# Altium schematic sheet uController.SchDoc
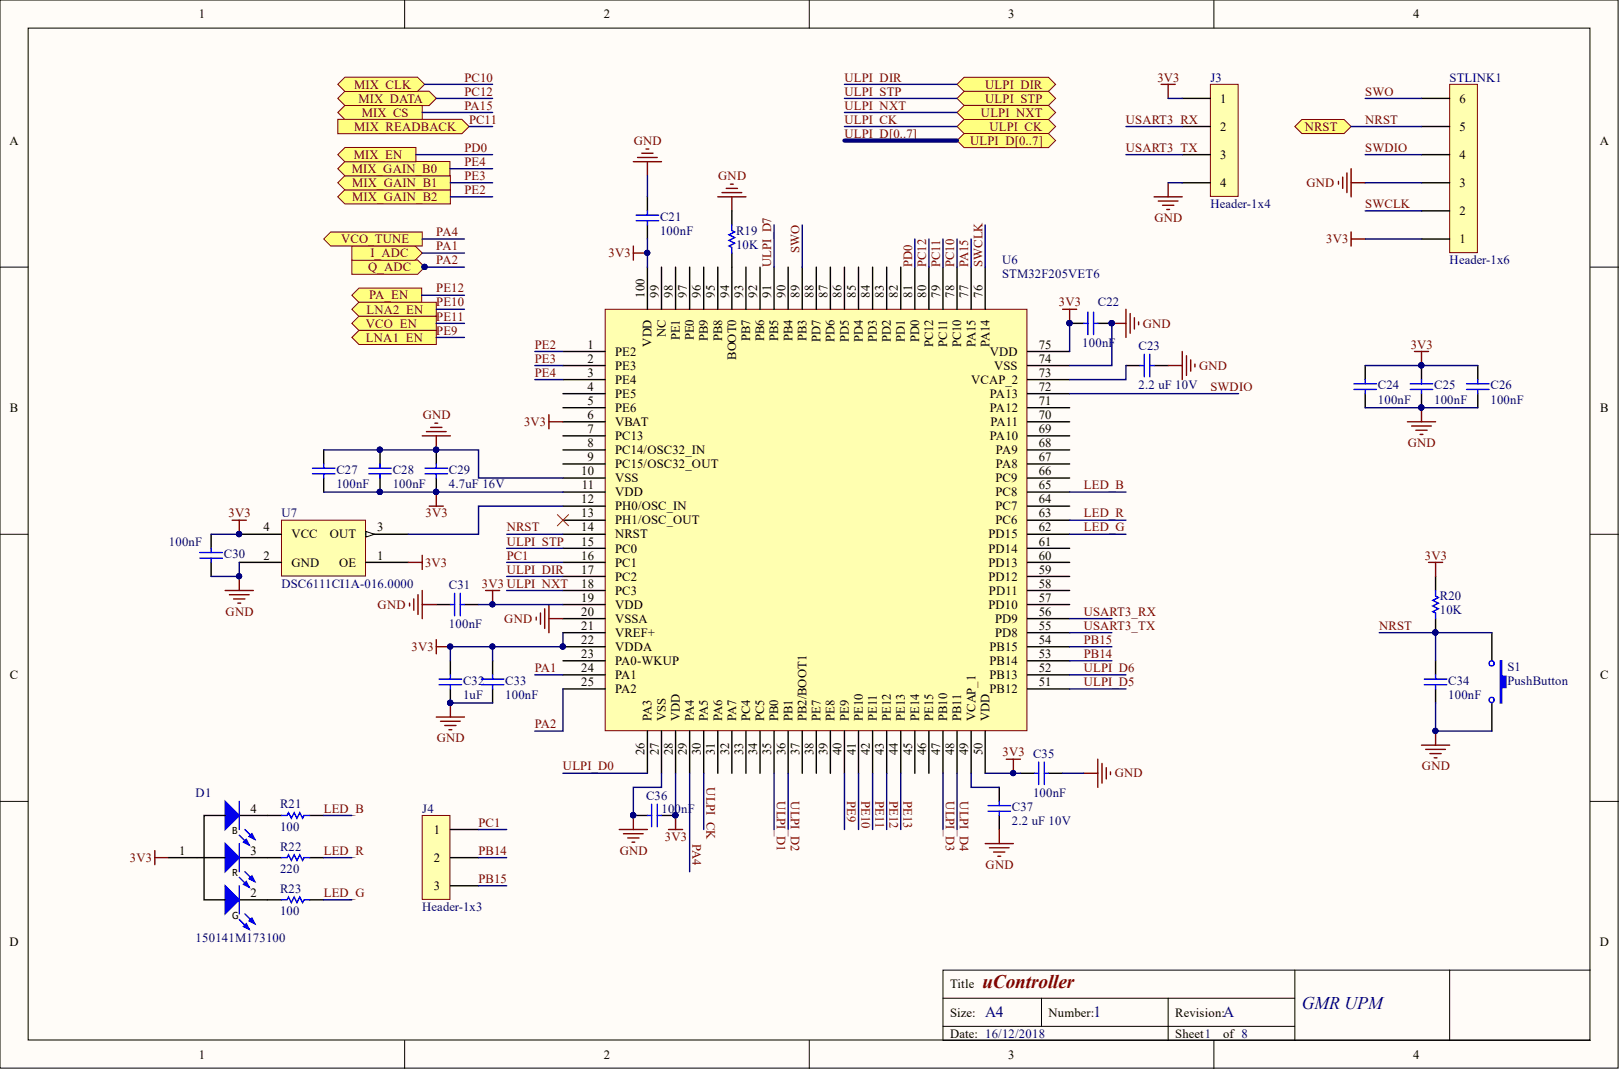
[diagram p0: uController.SchDoc]

## Parts
C21  100nF  [0603 Cap]
C22  100nF  [0603 Cap]
C23  2.2 uF 10V  Voltage - Rated: 10V  [0603 Cap]
C24  100nF  [0603 Cap]
C25  100nF  [0603 Cap]
C26  100nF  [0603 Cap]
C27  100nF  [0603 Cap]
C28  100nF  [0603 Cap]
C29  4.7uF 16V  [0805 CAP]
C30  100nF  [0603 Cap]
C31  100nF  [0603 Cap]
C32  1uF  [0603 Cap]
C33  100nF  [0603 Cap]
C34  100nF  [0603 Cap]
C35  100nF  [0603 Cap]
C36  100nF  [0603 Cap]
C37  2.2 uF 10V  [0603 Cap]
D1  150141M173100  [DFN270P320X210-4N]
J3  Header-1x4  [HEADER-1X4]
J4  Header-1x3  [Header-1x3-Jumper]
R19  10K  [0603 Res]
R20  10K  [0603 Res]
R21  100  [RESC1005X04L]
R22  220  [RESC1005X04L]
R23  100  [RESC1005X04L]
S1  PushButton  [GH7643CT-ND]
STLINK1  Header-1x6  [Header-1x6]
U6  STM32F205VET6  [LQFP-100]
U7  DSC6111CI1A-016.0000  [DFN 3.2x2.5]

## Ports
I_ADC, LNA1_EN, LNA2_EN, MIX_CLK, MIX_CS, MIX_DATA, MIX_EN, MIX_GAIN_B0, MIX_GAIN_B1, MIX_GAIN_B2, MIX_READBACK, NRST, PA_EN, Q_ADC, ULPI_CK, ULPI_D0, ULPI_D1, ULPI_D2, ULPI_D3, ULPI_D4, ULPI_D5, ULPI_D6, ULPI_D7, ULPI_D[0..7], ULPI_DIR, ULPI_NXT, ULPI_STP, VCO_EN, VCO_TUNE

## Nets
3V3: C21.2 C22.1 C24.2 C25.2 C26.2 C27.1 C28.1 C29.1 C30.2 C31.1 C32.2 C33.1 C35.2 C36.1 D1.1 J3.1 R20.2 STLINK1.1 U6.6(VBAT) U6.11(VDD) U6.19(VDD) U6.21(VREF+) U6.22(VDDA) U6.28(VDD) U6.50(VDD) U6.75(VDD) U6.100(VDD) U7.1(OE) U7.4(VCC)
GND: C21.1 C22.2 C23.1 C24.1 C25.1 C26.1 C27.2 C28.2 C29.2 C30.1 C31.2 C32.1 C33.2 C34.1 C35.1 C36.2 C37.1 J3.4 R19.2 S1.2 STLINK1.3 U6.10(VSS) U6.20(VSSA) U6.27(VSS) U6.74(VSS) U7.2(GND)
LED_B: R21.2 U6.65(PC8)
LED_G: R23.2 U6.62(PD15)
LED_R: R22.2 U6.63(PC6)
NRST: C34.2 R20.1 S1.1 STLINK1.5 U6.14(NRST) ; port NRST
PA1: U6.24(PA1) ; port I_ADC
PA2: U6.25(PA2) ; port Q_ADC
PA4: U6.29(PA4) ; port VCO_TUNE
PA15: U6.77(PA15) ; port MIX_CS
PB14: J4.2 U6.53(PB14)
PB15: J4.3 U6.54(PB15)
PC1: J4.1 U6.16(PC1)
PC10: U6.78(PC10) ; port MIX_CLK
PC11: U6.79(PC11) ; port MIX_READBACK
PC12: U6.80(PC12) ; port MIX_DATA
PD0: U6.81(PD0) ; port MIX_EN
PE2: U6.1(PE2) ; port MIX_GAIN_B2
PE3: U6.2(PE3) ; port MIX_GAIN_B1
PE4: U6.3(PE4) ; port MIX_GAIN_B0
PE9: U6.40(PE9) ; port LNA1_EN
PE10: U6.41(PE10) ; port LNA2_EN
PE11: U6.42(PE11) ; port VCO_EN
PE12: U6.43(PE12) ; port PA_EN
PE13: U6.44(PE13)
SWCLK: STLINK1.2 U6.76(PA14)
SWDIO: STLINK1.4 U6.72(PA13)
SWO: STLINK1.6 U6.89(PB3)
ULPI_CK: U6.30(PA5) ; port ULPI_CK
ULPI_D0: U6.26(PA3) ; port ULPI_D[0..7]  [also labelled ULPI_D]
ULPI_D1: U6.35(PB0) ; port ULPI_D[0..7]  [also labelled ULPI_D]
ULPI_D2: U6.36(PB1) ; port ULPI_D[0..7]  [also labelled ULPI_D]
ULPI_D3: U6.47(PB10) ; port ULPI_D[0..7]  [also labelled ULPI_D]
ULPI_D4: U6.48(PB11) ; port ULPI_D[0..7]  [also labelled ULPI_D]
ULPI_D5: U6.51(PB12) ; port ULPI_D[0..7]  [also labelled ULPI_D]
ULPI_D6: U6.52(PB13) ; port ULPI_D[0..7]  [also labelled ULPI_D]
ULPI_D7: U6.91(PB5) ; port ULPI_D[0..7]  [also labelled ULPI_D]
ULPI_DIR: U6.17(PC2) ; port ULPI_DIR
ULPI_NXT: U6.18(PC3) ; port ULPI_NXT
ULPI_STP: U6.15(PC0) ; port ULPI_STP
USART3_RX: J3.2 U6.56(PD9)
USART3_TX: J3.3 U6.55(PD8)
NetC23_2: C23.2 U6.73(VCAP_2)
NetC37_2: C37.2 U6.49(VCAP_1)
NetD1_2: D1.2 R23.1
NetD1_3: D1.3 R22.1
NetD1_4: D1.4 R21.1
NetR19_1: R19.1 U6.94(BOOT0)
NetU6_12: U6.12(PH0/OSC_IN) U7.3(OUT)

Not connected: U6 4(PE5) 5(PE6) 7(PC13) 8(PC14/OSC32_IN) 9(PC15/OSC32_OUT) 13(PH1/OSC_OUT) 23(PA0-WKUP) 31(PA6) 32(PA7) 33(PC4) 34(PC5) 37(PB2/BOOT1) 38(PE7) 39(PE8) 45(PE14) 46(PE15) 57(PD10) 58(PD11) 59(PD12) 60(PD13) 61(PD14) 64(PC7) 66(PC9) 67(PA8) 68(PA9) 69(PA10) 70(PA11) 71(PA12) 82(PD1) 83(PD2) 84(PD3) 85(PD4) 86(PD5) 87(PD6) 88(PD7) 90(PB4) 92(PB6) 93(PB7) 95(PB8) 96(PB9) 97(PE0) 98(PE1) 99(NC)

## Library data of the parts
C21 C22 C24-C28 C30 C31 C33-C36 = C0603C104K4RACTU: CAP CER 0.1UF 16V X7R 0603; Manufacturer: KEMET; Capacitance: 0.1µF; Tolerance: ±10%; Applications: General Purpose; Category: Capacitors; Component Kind: Standard; ComponentLink1Description: http://www.kemet.com/docfinder?Partnumber=C0603C104K4RACTU; ComponentLink1URL: http://www.kemet.com/docfinder?Partnumber=C0603C104K4RACTU; Failure Rate: -; Features: -; Height - Seated (Max): -; Lead Spacing: -; Lead Style: -; Library Name: Componentes_base.SchLib; Manufacturer Part Number: C0603C104K4RACTU; Mounting Type: Surface Mount, MLCC; Operating Temperature: -55°C ~ 125°C; Package / Case: 0603 (1608 Metric); Packaging: Digi-Reel®; Part Status: Active; Pricing 1: 1=0.09, 10=0.022, 25=0.016, 50=0.0122, 100=0.01, 250=0.00836, 500=0.0071, 1000=0.00559 (EUR); Ratings: -; RoHS: RoHS Compliant; Size / Dimension: 0.063" L x 0.031" W (1.60mm x 0.80mm); Stock 1: 4491346; Supplier 1: Digi-Key; Supplier Part Number 1: 399-1096-6-ND; Temperature Coefficient: X7R; Thickness (Max): 0.034" (0.87mm); Voltage - Rated: 16V
C23 C37 = CL10B225KP8NNNC: CAP CER 2.2UF 10V X7R 0603; Manufacturer: Samsung Electro-Mechanics America, Inc.; Capacitance: 2.2µF; Tolerance: ±10%; Applications: General Purpose; Category: Capacitors; Component Kind: Standard; ComponentLink1Description: http://www.samsungsem.com/kr/support/product-search/mlcc/__icsFiles/afieldfile/2016/08/18/S_CL10B225KP8NNNC.pdf; ComponentLink1URL: http://www.samsungsem.com/kr/support/product-search/mlcc/__icsFiles/afieldfile/2016/08/18/S_CL10B225KP8NNNC.pdf; Features: -; Height - Seated (Max): -; Lead Spacing: -; Lead Style: -; Library Name: Componentes_base.SchLib; Manufacturer Part Number: CL10B225KP8NNNC; Mounting Type: Surface Mount, MLCC; Operating Temperature: -55°C ~ 125°C; Package / Case: 0603 (1608 Metric); Packaging: Digi-Reel®; Part Status: Active; Pricing 1: 1=0.15, 10=0.105, 25=0.0764, 50=0.0592, 100=0.0491, 250=0.04068, 500=0.03444, 1000=0.02851 (EUR); Ratings: -; RoHS: RoHS Compliant; Size / Dimension: 0.063" L x 0.031" W (1.60mm x 0.80mm); Stock 1: 226342; Supplier 1: Digi-Key; Supplier Part Number 1: 1276-1134-6-ND; Temperature Coefficient: X7R; Thickness (Max): 0.035" (0.90mm)
  C37: Voltage - Rated: 10V
C29 = GRM21BR71C475KE51L: CAP CER 4.7UF 16V X7R 0805; Manufacturer: Murata Electronics North America; Capacitance: 4.7µF; Tolerance: ±10%; Applications: General Purpose; Category: Capacitors; Component Kind: Standard; Failure Rate: -; Features: -; Height - Seated (Max): -; Lead Spacing: -; Lead Style: -; Library Name: Componentes_base.SchLib; Manufacturer Part Number: GRM21BR71C475KE51L; Mounting Type: Surface Mount, MLCC; Operating Temperature: -55°C ~ 125°C; Package / Case: 0805 (2012 Metric); Part Status: Active; Pricing 1: 1=0.16, 10=0.111, 25=0.0816, 50=0.0666, 100=0.0562, 250=0.04588, 500=0.03848, 1000=0.03403 (EUR); Ratings: -; RoHS: RoHS Compliant; Size / Dimension: 0.079" L x 0.049" W (2.00mm x 1.25mm); Stock 1: 5810; Supplier 1: Digi-Key; Supplier Part Number 1: 490-14466-6-ND; Temperature Coefficient: X7R; Thickness (Max): 0.055" (1.40mm); Voltage - Rated: 16V
C32 = CL10B105MO8NNWC: CAP CER 1UF 16V X7R 0603; Manufacturer: Samsung Electro-Mechanics America, Inc.; Capacitance: 1µF; Tolerance: ±20%; Applications: General Purpose; Category: Capacitors; Component Kind: Standard; ComponentLink1Description: http://www.samsungsem.com/kr/support/library/product-catalog/__icsFiles/afieldfile/2016/09/27/MLCC.pdf; ComponentLink1URL: http://www.samsungsem.com/kr/support/library/product-catalog/__icsFiles/afieldfile/2016/09/27/MLCC.pdf; Features: -; Height - Seated (Max): -; Lead Spacing: -; Lead Style: -; Library Name: Componentes_base.SchLib; Manufacturer Part Number: CL10B105MO8NNWC; Mounting Type: Surface Mount, MLCC; Operating Temperature: -55°C ~ 125°C; Package / Case: 0603 (1608 Metric); Packaging: Digi-Reel®; Part Status: Active; Pricing 1: 1=0.09, 10=0.02, 25=0.0144, 50=0.0108, 100=0.0089, 250=0.00744, 500=0.00636, 1000=0.00499 (EUR); Ratings: -; RoHS: RoHS Compliant; Size / Dimension: 0.063" L x 0.031" W (1.60mm x 0.80mm); Stock 1: 77746; Supplier 1: Digi-Key; Supplier Part Number 1: 1276-6524-6-ND; Temperature Coefficient: X7R; Thickness (Max): 0.035" (0.90mm); Voltage - Rated: 16V
D1 = 150141M173100: LED RGB CLEAR 1411 SMD; Manufacturer: Wurth Electronics Inc.; Color: Red, Green, Blue (RGB); Configuration: Common Anode; Current - Test: 20mA Red, 20mA Green, 20mA Blue; Lens Color: Colorless; Lens Style/Size: Round with Flat Top, 2.40mm; Millicandela Rating: 270mcd Red, 950mcd Green, 230mcd Blue; Viewing Angle: 120°; Voltage - Forward (Vf) (Typ): 2V Red, 3.2V Green, 3.2V Blue; Wavelength - Dominant: 625nm Red, 520nm Green, 470nm Blue; Wavelength - Peak: 635nm Red, 515nm Green, 465nm Blue; Category: Optoelectronics; Component Kind: Standard; ComponentLink1Description: http://katalog.we-online.de/led/datasheet/150141M173100.pdf; ComponentLink1URL: http://katalog.we-online.de/led/datasheet/150141M173100.pdf; Features: -; Height (Max): 2.10mm; Lens Transparency: Clear; Library Name: Componentes_base.SchLib; Manufacturer Part Number: 150141M173100; Mounting Type: Surface Mount; Package / Case: 1411 (3528 Metric); Packaging: Cut Tape (CT); Part Status: Active; Pricing 1: 1=0.4, 100=0.3462, 500=0.2867 (EUR); RoHS: RoHS Compliant; Size / Dimension: 3.50mm L x 2.80mm W; Stock 1: 7809; Supplier 1: Digi-Key; Supplier Device Package: 3528; Supplier Part Number 1: 732-4998-1-ND
R19 R20 = RC0603FR-0710KL: RES SMD 10K OHM 1% 1/10W 0603; Manufacturer: Yageo; Power (Watts): 0.1W, 1/10W; Temperature Coefficient: ±100ppm/°C; Category: Resistors; Component Kind: Standard; ComponentLink1Description: http://www.yageo.com/documents/recent/PYu-RC_Group_51_RoHS_L_7.pdf; ComponentLink1URL: http://www.yageo.com/documents/recent/PYu-RC_Group_51_RoHS_L_7.pdf; Composition: Thick Film; Failure Rate: -; Features: Moisture Resistant; Height - Seated (Max): 0.022" (0.55mm); Library Name: Componentes_base.SchLib; Manufacturer Part Number: RC0603FR-0710KL; Operating Temperature: -55°C ~ 155°C; Package / Case: 0603 (1608 Metric); Packaging: Cut Tape (CT); Part Status: Active; Pricing 1: 1=0.09, 10=0.012, 25=0.0088, 50=0.0066, 100=0.0049, 250=0.00372, 500=0.00296, 1000=0.00218 (EUR); Resistance (Ohms): 10k; RoHS: RoHS Compliant; Size / Dimension: 0.063" L x 0.031" W (1.60mm x 0.80mm); Stock 1: 17023109; Supplier 1: Digi-Key; Supplier Device Package: 0603; Supplier Part Number 1: 311-10.0KHRCT-ND; Tolerance: ±1%
R21 R23 = MCR01MRTF1000: RES 100 OHM 1/16W 1% 0402 SMD; Manufacturer: Rohm Semiconductor; Power (Watts): 0.063W, 1/16W; Temperature Coefficient: ±100ppm/°C; Category: Resistors; Component Kind: Standard; ComponentLink1Description: http://rohmfs.rohm.com/en/products/databook/datasheet/passive/resistor/chip_resistor/mcr_g.pdf; ComponentLink1URL: http://rohmfs.rohm.com/en/products/databook/datasheet/passive/resistor/chip_resistor/mcr_g.pdf; Composition: Thick Film; Features: -; Height: 0.016" (0.40mm); Library Name: Componentes_base.SchLib; Manufacturer Part Number: MCR01MRTF1000; Number of Terminations: 2; Operating Temperature: -55°C ~ 155°C; Package / Case: 0402 (1005 Metric); Packaging: Cut Tape (CT); Pricing 1: 1=0.09, 10=0.011, 25=0.008, 100=0.0046, 250=0.00348, 500=0.00278, 1000=0.00205, 5000=0.00147 (EUR); Resistance (Ohms): 100; RoHS: RoHS Compliant; Size / Dimension: 0.039" L x 0.020" W (1.00mm x 0.50mm); Stock 1: 128227; Supplier 1: Digi-Key; Supplier Device Package: 0402 (1005 Metric); Supplier Part Number 1: RHM100CDCT-ND; Tolerance: ±1%
R22 = RC0402FR-07220RL: RES SMD 220 OHM 1% 1/16W 0402; Manufacturer: Yageo; Power (Watts): 0.063W, 1/16W; Temperature Coefficient: ±100ppm/°C; Category: Resistors; Component Kind: Standard; ComponentLink1Description: http://www.yageo.com/NewPortal/yageodocoutput?fileName=/pdf/R-Chip/PYu-RC_Group_51_RoHS_L_4.pdf; ComponentLink1URL: http://www.yageo.com/NewPortal/yageodocoutput?fileName=/pdf/R-Chip/PYu-RC_Group_51_RoHS_L_4.pdf; Composition: Thick Film; Failure Rate: -; Features: Moisture Resistant; Height - Seated (Max): 0.016" (0.40mm); Library Name: Componentes_base.SchLib; Manufacturer Part Number: RC0402FR-07220RL; Number of Terminations: 2; Operating Temperature: -55°C ~ 155°C; Package / Case: 0402 (1005 Metric); Packaging: Cut Tape (CT); Part Status: Active; Pricing 1: 1=0.09, 10=0.011, 25=0.0084, 50=0.0062, 100=0.0046, 250=0.00348, 500=0.00278, 1000=0.00205, 5000=0.00147 (EUR); Resistance (Ohms): 220; RoHS: RoHS Compliant; Size / Dimension: 0.039" L x 0.020" W (1.00mm x 0.50mm); Stock 1: 624682; Supplier 1: Digi-Key; Supplier Device Package: 0402; Supplier Part Number 1: 311-220LRCT-ND; Tolerance: ±1%
S1 = PushButton_95C04A3GWRT: SWITCH TACTILE SPST-NO 0.05A 12V; Manufacturer: Grayhill Inc.; Actuator Height off PCB, Vertical: 4.30mm; Actuator Material: Polyamide (PA), Nylon; Actuator Orientation: Top Actuated; Actuator Type: Rectangular Button; Contact Rating @ Voltage: 0.05A @ 12VDC; Illumination: Non-Illuminated; Mechanical Life: 50,000 Cycles; Operating Force: 160gf; Outline: 6.00mm x 3.50mm; Switch Function: Off-Mom; Switch Travel: 0.25mm; Actuator Length, Right Angle: -; Category: Switches; Circuit: SPST-NO; Component Kind: Standard; ComponentLink1Description: http://www.grayhill.com/assets/1/7/Tact_95C04.pdf; ComponentLink1URL: http://www.grayhill.com/assets/1/7/Tact_95C04.pdf; Features: Tactile Feedback; Illumination Type, Color: -; Illumination Voltage (Nominal): -; Ingress Protection: -; Library Name: Schlib1.SchLib; Manufacturer Part Number: 95C04A3GWRT; Mounting Type: Surface Mount; Operating Temperature: -25°C ~ 70°C; Packaging: Cut Tape (CT); Part Status: Active; Pricing 1: 1=0.38, 10=0.363, 25=0.3556, 50=0.3424, 100=0.2954, 250=0.26852, 500=0.2551 (EUR); RoHS: RoHS Compliant; Stock 1: 3272; Supplier 1: Digi-Key; Supplier Part Number 1: GH7643CT-ND; Termination Style: Gull Wing
U6 = STM32F205VET6: IC MCU 32BIT 512KB FLASH 100LQFP; Manufacturer: STMicroelectronics; Connectivity: CAN, I²C, IrDA, LIN, MMC, SPI, UART/USART, USB OTG; Core Processor: ARM® Cortex®-M3; Core Size: 32-Bit; Data Converters: A/D 16x12b; D/A 2x12b; Number of I/O: 82; Oscillator Type: Internal; Peripherals: Brown-out Detect/Reset, DMA, I²S, LCD, POR, PWM, WDT; Program Memory Size: 512KB (512K x 8); RAM Size: 132K x 8; Speed: 120MHz; Voltage - Supply (Vcc/Vdd): 1.8 V ~ 3.6 V; Category: Integrated Circuits (ICs); Component Kind: Standard; ComponentLink1Description: http://media.digikey.com/pdf/Data%20Sheets/ST%20Microelectronics%20PDFS/STM32F20(5,7)xx.pdf; ComponentLink1URL: http://media.digikey.com/pdf/Data%20Sheets/ST%20Microelectronics%20PDFS/STM32F20(5,7)xx.pdf; EEPROM Size: -; Library Name: Componentes_base.SchLib; Manufacturer Part Number: STM32F205VET6; Operating Temperature: -40°C ~ 85°C (TA); Package / Case: 100-LQFP; Packaging: Tray; Part Status: Active; Pricing 1: 1=9.78, 10=8.83, 100=7.3108, 500=6.36612, 1000=5.54468 (EUR); Program Memory Type: FLASH; RoHS: RoHS Compliant; Stock 1: 1188; Supplier 1: Digi-Key; Supplier Device Package: 100-LQFP (14x14); Supplier Part Number 1: 497-11160-ND
U7 = DSC6111CI1A-016.0000: ULTRA-LOW POWER,MEMS OSCILLATOR,; Manufacturer: Microchip Technology; Current - Supply (Disable) (Max): 10.5µA; Current - Supply (Max): 3mA (Typ); Frequency: 16MHz; Frequency Stability: ±25ppm; Function: Standby (Power Down); Output: CMOS; Voltage - Supply: 1.71 V ~ 3.63 V; Category: Crystals, Oscillators, Resonators; Component Kind: Standard; ComponentLink1Description: http://www.microchip.com/mymicrochip/filehandler.aspx?ddocname=en587492; ComponentLink1URL: http://www.microchip.com/mymicrochip/filehandler.aspx?ddocname=en587492; Height - Seated (Max): 0.035" (0.90mm); Library Name: Componentes_base.SchLib; Manufacturer Part Number: DSC6111CI1A-016.0000; Mounting Type: Surface Mount; Operating Temperature: -40°C ~ 85°C; Package / Case: 4-SMD, No Lead; Packaging: Tube; Part Status: Active; Pricing 1: 1=0.81, 25=0.6744, 100=0.6514 (EUR); Ratings: AEC-Q100; RoHS: RoHS Compliant; Size / Dimension: 0.126" L x 0.098" W (3.20mm x 2.50mm); Stock 1: 957; Supplier 1: Digi-Key; Supplier Part Number 1: DSC6111CI1A-016.0000-ND; Type: MEMS (Silicon)
J3 = Header-1x4: Component Kind: Standard; Library Name: B105connectors.SchLib
J4 = Header-1x3: Component Kind: Standard; Library Name: B105lib.IntLib
STLINK1 = Header-1x6: Component Kind: Standard; Library Name: B105connectors.SchLib
